# Altium schematic sheet Battery_BMS.SchDoc("Battery & BMS")
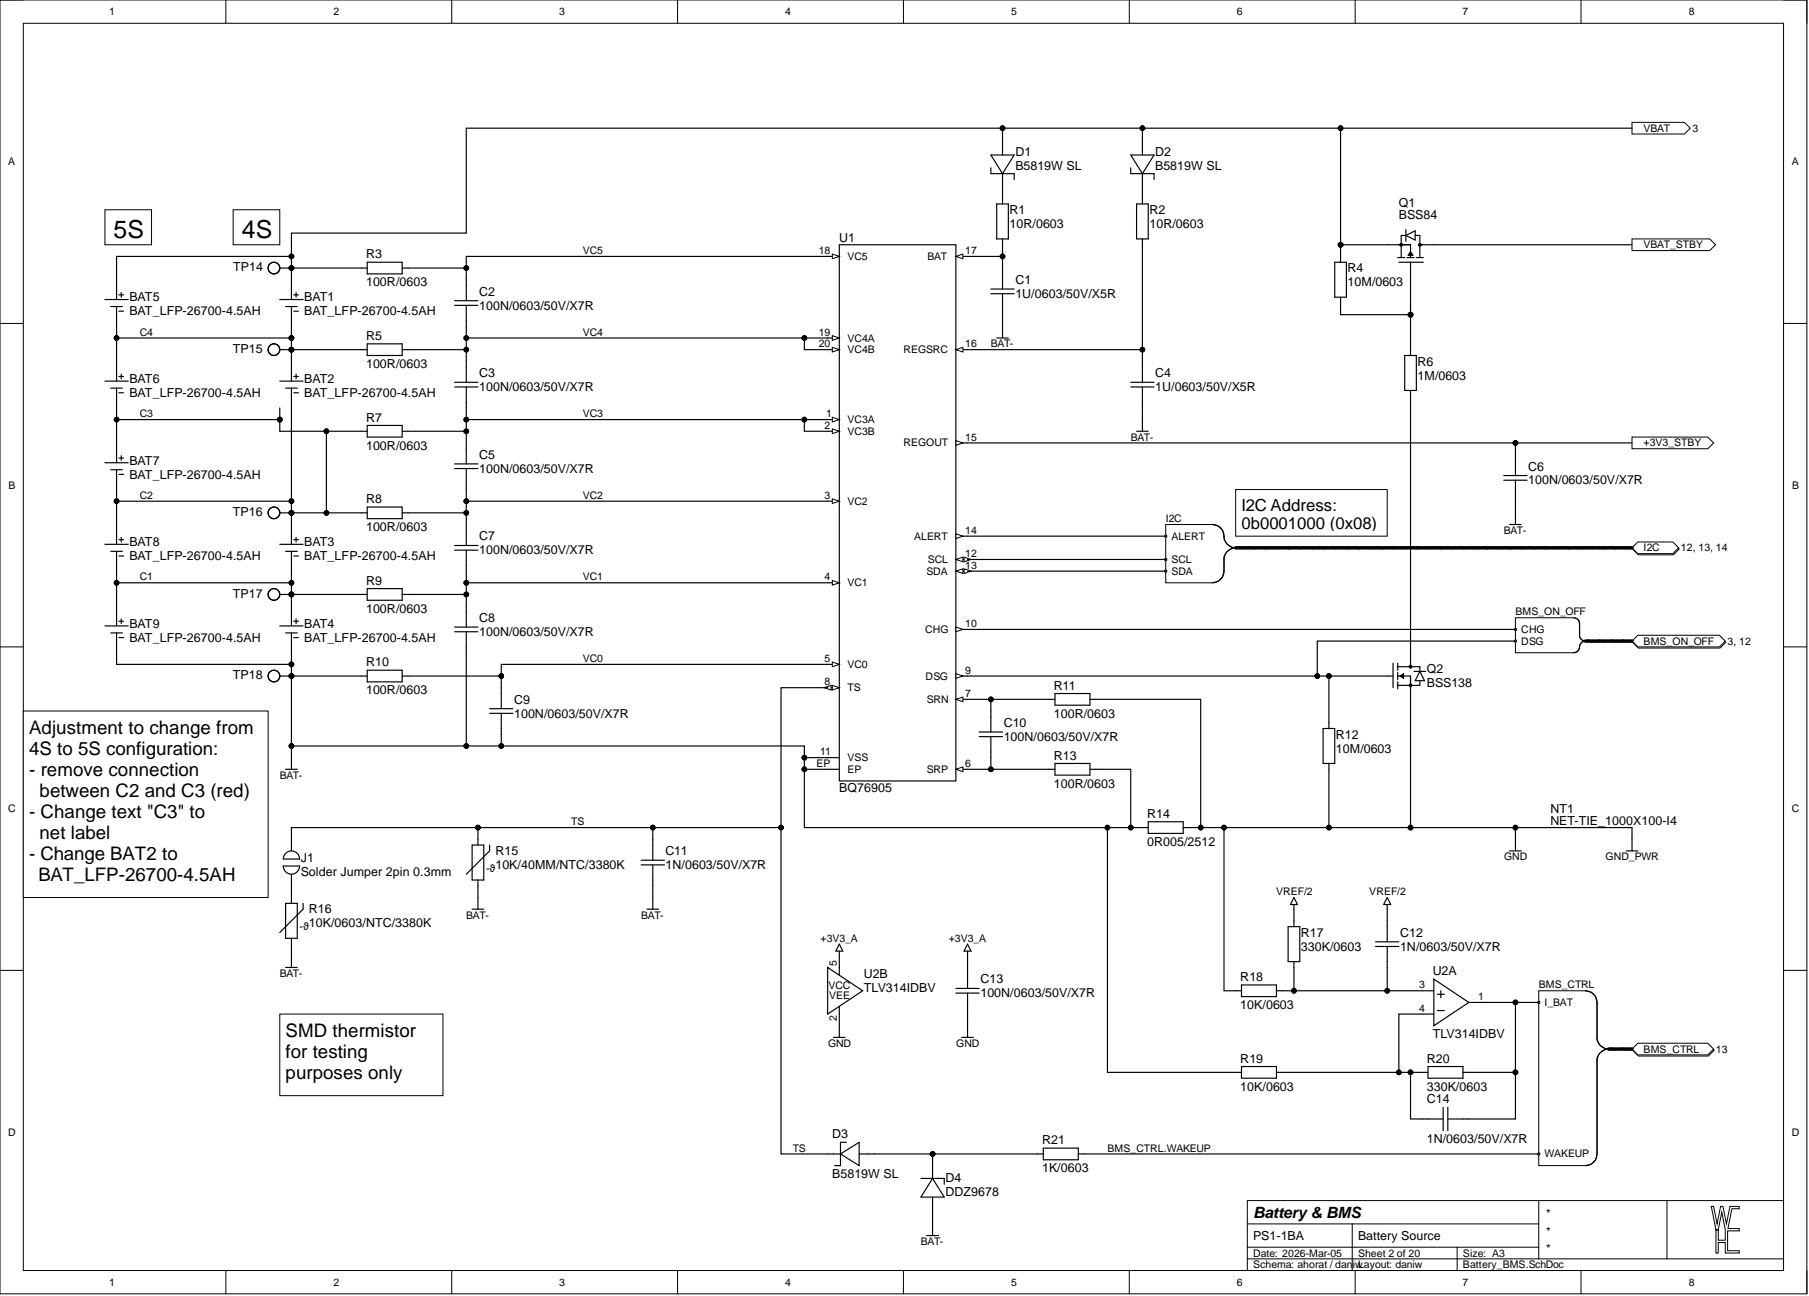
[diagram p0: Battery_BMS.SchDoc]

## Parts
BAT1  BAT_LFP-26700-4.5AH  [BAT-26700-PS]
BAT2  BAT_LFP-26700-4.5AH  [BAT-26700-PS]
BAT3  BAT_LFP-26700-4.5AH  [BAT-26700-PS]
BAT4  BAT_LFP-26700-4.5AH  [BAT-26700-PS]
C1  1U/0603/50V/X5R  [CAP0603_X7R]
C2  100N/0603/50V/X7R  [CAP0603_X7R]
C3  100N/0603/50V/X7R  [CAP0603_X7R]
C4  1U/0603/50V/X5R  [CAP0603_X7R]
C5  100N/0603/50V/X7R  [CAP0603_X7R]
C6  100N/0603/50V/X7R  [CAP0603_X7R]
C7  100N/0603/50V/X7R  [CAP0603_X7R]
C8  100N/0603/50V/X7R  [CAP0603_X7R]
C9  100N/0603/50V/X7R  [CAP0603_X7R]
C10  100N/0603/50V/X7R  [CAP0603_X7R]
C11  1N/0603/50V/X7R  [CAP0603_X7R]
C12  1N/0603/50V/X7R  [CAP0603_X7R]
C13  100N/0603/50V/X7R  [CAP0603_X7R]
C14  1N/0603/50V/X7R  [CAP0603_X7R]
D1  B5819W SL  [SOD3718X125]
D2  B5819W SL  [SOD3718X125]
D3  B5819W SL  [SOD3718X125]
D4  DDZ9678  [SOD3718X125]
J1  Solder Jumper 2pin 0.3mm  [Solder_Jumper_2pin_0.3mm]
NT1  NET-TIE_1000X100-I4  [NET-TIE_1000X100]
Q1  BSS84  [SOT2395P280X125-3-SE]
Q2  BSS138  [SOT2395P280X125-3-SE]
R1  10R/0603  [R0603-0.40-WW]
R2  10R/0603  [R0603-0.40-WW]
R3  100R/0603  [R0603-0.40-WW]
R4  10M/0603  [R0603-0.40-WW]
R5  100R/0603  [R0603-0.40-WW]
R6  1M/0603  [R0603-0.40-WW]
R7  100R/0603  [R0603-0.40-WW]
R8  100R/0603  [R0603-0.40-WW]
R9  100R/0603  [R0603-0.40-WW]
R10  100R/0603  [R0603-0.40-WW]
R11  100R/0603  [R0603-0.40-WW]
R12  10M/0603  [R0603-0.40-WW]
R13  100R/0603  [R0603-0.40-WW]
R14  0R005/2512  [R2512-0.65-WW]
R15  10K/40MM/NTC/3380K  [RESD200W25D200H4000]
R16  10K/0603/NTC/3380K  [R0603-0.40-WW]
R17  330K/0603  [R0603-0.40-WW]
R18  10K/0603  [R0603-0.40-WW]
R19  10K/0603  [R0603-0.40-WW]
R20  330K/0603  [R0603-0.40-WW]
R21  1K/0603  [R0603-0.40-WW]
TP14  TP_THD_1.5/0.8  [TP_THD_1.5/0.8]  (value not drawn)  (no BOM)
TP15  TP_THD_1.5/0.8  [TP_THD_1.5/0.8]  (value not drawn)  (no BOM)
TP16  TP_THD_1.5/0.8  [TP_THD_1.5/0.8]  (value not drawn)  (no BOM)
TP17  TP_THD_1.5/0.8  [TP_THD_1.5/0.8]  (value not drawn)  (no BOM)
TP18  TP_THD_1.5/0.8  [TP_THD_1.5/0.8]  (value not drawn)  (no BOM)
U1  BQ76905  [QFN050P350X350X100-20-EP200X200]
U2  TLV314IDBV  [SOT2395P280X125-5-NW]  (parts A,B)

## Ports
+3V3_STBY, BMS_CTRL, BMS_CTRL.I_BAT, BMS_CTRL.WAKEUP, BMS_ON_OFF, BMS_ON_OFF.CHG, BMS_ON_OFF.DSG, I2C, I2C.ALERT, I2C.SCL, I2C.SDA, VBAT, VBAT_STBY

## Nets
+3V3_A: C13.1 U2.5
BAT-: BAT4.2 C1.2 C4.2 C6.2 C8.2 C9.2 C11.2 D4.2 R10.1 R13.2 R14.1 R15.2 R16.2 R19.1 TP18.1 U1.11(VSS) U1.EP
GND: C13.2 NT1.1 Q2.2 R11.2 R12.2 R14.2 R18.1 U2.2
GND_PWR: NT1.2
VREF/2: C12.1 R17.1
+3V3_STBY: C6.1 U1.15(REGOUT) ; port +3V3_STBY
BMS_CTRL: C14.2 R20.2 U2.1 ; port BMS_CTRL
BMS_CTRL.WAKEUP: R21.2 ; port BMS_CTRL
C1: BAT3.2 BAT4.1 R9.1 TP17.1
C2: BAT2.2 BAT3.1 R7.1 R8.1 TP16.1
C4: BAT1.2 BAT2.1 R5.1 TP15.1
TS: C11.1 D3.1 J1.1 R15.1 U1.8(TS)
VBAT: BAT1.1 D1.2 D2.2 Q1.2 R3.1 R4.1 TP14.1 ; port VBAT
VBAT_STBY: Q1.3 ; port VBAT_STBY
VC0: C9.1 R10.2 U1.5(VC0)
VC1: C7.2 C8.1 R9.2 U1.4(VC1)
VC2: C5.2 C7.1 R8.2 U1.3(VC2)
VC3: C3.2 C5.1 R7.2 U1.1(VC3A) U1.2(VC3B)
VC4: C2.2 C3.1 R5.2 U1.19(VC4A) U1.20(VC4B)
VC5: C2.1 R3.2 U1.18(VC5)
NetC1_1: C1.1 R1.2 U1.17(BAT)
NetC4_1: C4.1 R2.2 U1.16(REGSRC)
NetC10_1: C10.1 R11.1 U1.7(SRN)
NetC10_2: C10.2 R13.1 U1.6(SRP)
NetC12_2: C12.2 R17.2 R18.2 U2.3
NetC14_1: C14.1 R19.2 R20.1 U2.4
NetD1_1: D1.1 R1.1
NetD2_1: D2.1 R2.1
NetD3_2: D3.2 D4.1 R21.1
NetJ1_2: J1.2 R16.1
NetQ1_1: Q1.1 R4.2 R6.1
NetQ2_1: Q2.1 R12.1 U1.9(DSG) ; port BMS_ON_OFF
NetQ2_3: Q2.3 R6.2
NetU1_10: U1.10(CHG) ; port BMS_ON_OFF
NetU1_12: U1.12(SCL) ; port I2C
NetU1_13: U1.13(SDA) ; port I2C
NetU1_14: U1.14(ALERT) ; port I2C

## Library data of the parts
BAT1-BAT4 = BAT_LFP-26700-4.5AH: Battery LiFePO4 26700 4.5Ah; Manufacturer: Tenpower; Manufacturer Part Number 1: IFR26700-45HE; Database Table Name: Misc$; Component Kind: Standard; HelpURL: https://www.tenpowercell.com/wp-content/uploads/2023/08/TP-IFR26700-45HE-Product-Datasheet-v1.4.pdf; Manufacturer 1: Tenpower; Part Number: BAT_LFP-26700-4.5AH; Supplier 1: NKON; Supplier Part Number 1: 6097321571573; Library Name: daniw_altium_lib.DbLib
C1 C4 = 1U/0603/50V/X5R: CAP SM 0603 1.0uF 50V X5R; Manufacturer: GENERIC; Manufacturer 1: Samsung Electro-Mechanics; Manufacturer Part Number 1: CL10A105KB8NNNC; Absolute Value: 1E-6; Database Table Name: C$; Eurocircuits GPN: GPC0603105-X5R; Component Kind: Standard; HelpURL: https://mm.digikey.com/Volume0/opasdata/d220001/medias/docus/658/CL10A105KB8NNNC_Spec.pdf; JLC Type: Basic; Manufacturer Part Number 3: CL10A105KB8NNNC; Package: 0603; Part Number: 1U/0603/50V/X5R; Supplier 1: Digi-Key; Supplier 3: LCSC; Supplier Part Number 1: 1276-1860-1-ND; Supplier Part Number 3: C15849; Value: 1.0uF; Library Name: daniw_altium_lib.DbLib
C2 C3 C5-C10 C13 = 100N/0603/50V/X7R: CAP SM 0603 100nF 50V X7R; Manufacturer: GENERIC; Manufacturer 1: YAGEO; Manufacturer Part Number 1: CC0603KPX7R9BB104; Absolute Value: 1E-7; Database Table Name: C$; Eurocircuits GPN: GPC0603104; Component Kind: Standard; HelpURL: https://www.yageo.com/upload/media/product/app/datasheet/mlcc/upy-gphc_x7r_6_3v-to-250v.pdf; JLC Type: Basic; Manufacturer 2: YAGEO; Manufacturer Part Number 2: CC0603MRX7R9BB104; Manufacturer Part Number 3: CC0603KRX7R9BB104; Package: 0603; Part Number: 100N/0603/50V/X7R; Supplier 1: Digi-Key; Supplier 2: Digi-Key; Supplier 3: LCSC; Supplier Part Number 1: 311-3567-1-ND; Supplier Part Number 2: 311-4110-1-ND; Supplier Part Number 3: C14663; Value: 100nF; Library Name: daniw_altium_lib.DbLib
C11 C12 C14 = 1N/0603/50V/X7R: CAP SM 0603 1.0nF 50V X7R; Manufacturer: GENERIC; Manufacturer 1: YAGEO; Manufacturer Part Number 1: CC0603KPX7R9BB102; Absolute Value: 1E-9; Database Table Name: C$; Eurocircuits GPN: GPC0603102; Component Kind: Standard; HelpURL: https://www.yageo.com/upload/media/product/app/datasheet/mlcc/upy-gphc_x7r_6_3v-to-250v.pdf; JLC Type: Basic; Manufacturer 2: YAGEO; Manufacturer Part Number 2: CC0603KRX7R9BB102; Manufacturer Part Number 3: CL10B102KB8NNNC; Package: 0603; Part Number: 1N/0603/50V/X7R; Supplier 1: Digi-Key; Supplier 2: Digi-Key; Supplier 3: LCSC; Supplier Part Number 1: 13-CC0603KPX7R9BB102CT-ND; Supplier Part Number 2: 311-1080-1-ND; Supplier Part Number 3: C1588; Value: 1.0nF; Library Name: daniw_altium_lib.DbLib
D1-D3 = B5819W SL: 40V 600mV@1A 1A SOD-123 Schottky Barrier Diodes; Manufacturer: GENERIC; Manufacturer 1: SMC Diode Solutions; Database Table Name: D$; Absolute Value: 40V; Component Kind: Standard; HelpURL: https://datasheet.lcsc.com/lcsc/2304140030_Jiangsu-Changjing-Electronics-Technology-Co---Ltd--B5819W-SL_C8598.pdf; JLC Type: Basic; Manufacturer 3: Jiangsu Changjing Electronics Technology Co., Ltd.; Manufacturer Part Number 1: B5819WSL; Manufacturer Part Number 3: B5819W SL; Package: SOD-123; Part Number: B5819W SL; Supplier 1: Digikey; Supplier 3: LCSC; Supplier Part Number 1: 1655-B5819WSLCT-ND; Supplier Part Number 3: C8598; Value: B5819W SL; Library Name: daniw_altium_lib.DbLib
D4 = DDZ9678: Low current zener diode 1.8V 500mW SOD123; Manufacturer: Diodes Incorporated; Manufacturer Part Number 1: DDZ9678-7; Database Table Name: D$; Absolute Value: 1.8V; Component Kind: Standard; HelpURL: https://www.diodes.com/datasheet/download/DDZ96xx-DDZ97xx.pdf; JLC Type: Extended; Manufacturer 1: Diodes Incorporated; Manufacturer 3: DIODES; Manufacturer Part Number 3: DDZ9678-7; Package: SOD-123; Part Number: DDZ9678; Supplier 1: Digikey; Supplier 3: LCSC; Supplier Part Number 1: DDZ9678DICT-ND; Supplier Part Number 3: C150464; Value: 1.8V; Library Name: daniw_altium_lib.DbLib
J1 = Solder_Jumper_2pin_0.3mm: Solder jumper with two pins with a spacing of 0.3mm; Manufacturer: NONE; Database Table Name: Misc$; Component Kind: Standard; Part Number: Solder_Jumper_2pin_0.3mm; Library Name: daniw_altium_lib.DbLib
NT1 = NET-TIE_1000X100-I4: Net-Tie 10.00mmx1.00mm Inner Layer 4; Manufacturer: NONE; Database Table Name: Net-Tie$; Component Kind: Net Tie; Layer: Inner Layer 4; Length: 1.00mm; Part Number: NET-TIE_1000X100-I4; Width: 10.00m; Library Name: daniw_altium_lib.DbLib
Q1 = BSS84: 50V P-Channel MOSFET; Manufacturer: GENERIC; Manufacturer 1: Good-Ark Semiconductor; Database Table Name: T$; Component Kind: Standard; HelpURL: https://goodarksemi.com/docs/datasheets/mosfets/BSS84.pdf; JLC Type: Extended; Manufacturer 2: LRC; Manufacturer 3: Jiangsu Changjing Electronics Technology Co., Ltd.; Manufacturer Part Number 1: BSS84; Manufacturer Part Number 2: LBSS84LT1G; Manufacturer Part Number 3: BSS84; Package: SOT23; Part Number: BSS84; Supplier 1: Digi-Key; Supplier 2: LCSC; Supplier 3: LCSC; Supplier Part Number 1: 4786-BSS84CT-ND; Supplier Part Number 2: C8492; Supplier Part Number 3: C114481; Library Name: daniw_altium_lib.DbLib
Q2 = BSS138: N-Kanal 50 V 220mA (Ta) 350mW (Ta) Oberflächenmontage SOT-23; Manufacturer: GENERIC; Manufacturer 1: ANBON SEMICONDUCTOR (INT'L) LIMITED; Database Table Name: T$; Component Kind: Standard; HelpURL: https://mm.digikey.com/Volume0/opasdata/d220001/medias/docus/3037/BSS138.pdf; JLC Type: Extended; Manufacturer 2: FOSAN; Manufacturer 3: FOSAN; Manufacturer Part Number 1: BSS138; Manufacturer Part Number 2: BSS138; Manufacturer Part Number 3: BSS138; Package: SOT23; Part Number: BSS138; Supplier 1: Digi-Key; Supplier 2: LCSC; Supplier 3: LCSC; Supplier Part Number 1: 4530-BSS138CT-ND; Supplier Part Number 2: C2926186; Supplier Part Number 3: C2926186; Library Name: daniw_altium_lib.DbLib
R1 R2 = 10R/0603: RES SM 0603 10R; Manufacturer: GENERIC; Manufacturer 1: YAGEO; Manufacturer Part Number 1: RC0603FR-1310RL; Absolute Value: 10; Database Table Name: R$; Eurocircuits GPN: GPR060310R; Component Kind: Standard; HelpURL: https://www.yageogroup.com/content/datasheet/asset/file/PYU-RC_GROUP_51_ROHS_L; JLC Type: Basic; Manufacturer 2: YAGEO; Manufacturer 3: UNI-ROYAL; Manufacturer Part Number 2: RC0603FR-1010RL; Manufacturer Part Number 3: 0603WAF100JT5E; Package: 0603; Part Number: 10R/0603; Supplier 1: Digi-Key; Supplier 2: Digi-Key; Supplier 3: LCSC; Supplier Part Number 1: 13-RC0603FR-1310RLCT-ND; Supplier Part Number 2: 13-RC0603FR-1010RLCT-ND; Supplier Part Number 3: C22859; Value: 10R; Library Name: daniw_altium_lib.DbLib
R3 R5 R7-R11 R13 = 100R/0603: RES SM 0603 100R; Manufacturer: GENERIC; Manufacturer 1: YAGEO; Manufacturer Part Number 1: RC0603FR-13100RL; Absolute Value: 100; Database Table Name: R$; Eurocircuits GPN: GPR0603100R; Component Kind: Standard; HelpURL: https://www.yageogroup.com/content/datasheet/asset/file/PYU-RC_GROUP_51_ROHS_L; Manufacturer 2: YAGEO; Manufacturer 3: UNI-ROYAL(Uniroyal Elec); Manufacturer Part Number 2: RC0603FR-10100RL; Manufacturer Part Number 3: 0603WAF1000T5E; Package: 0603; Part Number: 100R/0603; Supplier 1: Digi-Key; Supplier 2: Digi-Key; Supplier 3: LCSC; Supplier Part Number 1: 13-RC0603FR-13100RLCT-ND; Supplier Part Number 2: 13-RC0603FR-10100RLCT-ND; Supplier Part Number 3: C22775; Value: 100R; Library Name: daniw_altium_lib.DbLib
R4 R12 = 10M/0603: RES SM 0603 10M; Manufacturer: GENERIC; Manufacturer 1: YAGEO; Manufacturer Part Number 1: RC0603FR-1310ML; Absolute Value: 10000000; Database Table Name: R$; Eurocircuits GPN: GPR060310M; Component Kind: Standard; HelpURL: https://www.yageogroup.com/content/datasheet/asset/file/PYU-RC_GROUP_51_ROHS_L; JLC Type: Basic; Manufacturer 2: YAGEO; Manufacturer 3: UNI-ROYAL(Uniroyal Elec); Manufacturer Part Number 2: RC0603FR-1010ML; Manufacturer Part Number 3: 0603WAF1005T5E; Package: 0603; Part Number: 10M/0603; Supplier 1: Digi-Key; Supplier 2: Digi-Key; Supplier 3: LCSC; Supplier Part Number 1: 13-RC0603FR-1310MLCT-ND; Supplier Part Number 2: 13-RC0603FR-1010MLCT-ND; Supplier Part Number 3: C7250; Value: 10M; Library Name: daniw_altium_lib.DbLib
R6 = 1M/0603: RES SM 0603 1M; Manufacturer: GENERIC; Manufacturer 1: YAGEO; Manufacturer Part Number 1: RC0603FR-131ML; Absolute Value: 1000000; Database Table Name: R$; Eurocircuits GPN: GPR06031M; Component Kind: Standard; HelpURL: https://www.yageogroup.com/content/datasheet/asset/file/PYU-RC_GROUP_51_ROHS_L; JLC Type: Basic; Manufacturer 2: YAGEO; Manufacturer 3: UNI-ROYAL(Uniroyal Elec); Manufacturer Part Number 2: RC0603FR-101ML; Manufacturer Part Number 3: 0603WAF1004T5E; Package: 0603; Part Number: 1M/0603; Supplier 1: Digi-Key; Supplier 2: Digi-Key; Supplier 3: LCSC; Supplier Part Number 1: 13-RC0603FR-131MLCT-ND; Supplier Part Number 2: 13-RC0603FR-101MLCT-ND; Supplier Part Number 3: C22935; Value: 1M; Library Name: daniw_altium_lib.DbLib
R14 = 0R005/2512: RES SM 2512 0R005; Manufacturer: GENERIC; Manufacturer 1: Ohmite; Manufacturer Part Number 1: MCS3264R005FER; Absolute Value: 0.005; Database Table Name: R$; Component Kind: Standard; HelpURL: https://www.ohmite.com/assets/docs/res_mcs.pdf?r=false; Manufacturer 3: prosemi; Manufacturer Part Number 3: LMP25MF2P0R005; Package: 2512; Part Number: 0R005/2512; Supplier 1: Digi-Key; Supplier 3: LCSC; Supplier Part Number 1: MCS3264R005FERCT-ND; Supplier Part Number 3: C2937402; Value: 0R005; Library Name: daniw_altium_lib.DbLib
R15 = 10K/40MM/NTC/3380K: RES NTC THT 40mm 10K 3380K; Manufacturer: GENERIC; Manufacturer 1: Eaton - Electronics Division; Manufacturer Part Number 1: NRSE104F3380B1F; Absolute Value: 10000; Database Table Name: R$; Component Kind: Standard; HelpURL: https://eaton.com/content/dam/eaton/products/electronic-components/resources/data-sheet/eaton-nrse-epoxy-sealed-radial-lead-ntc-thermistor-data-sheet-elx1227.pdf; Part Number: 10K/40MM/NTC/3380K; Supplier 1: Digi-Key; Supplier Part Number 1: 283-NRSE104F3380B1F-ND; Value: 10K; Library Name: daniw_altium_lib.DbLib
R16 = 10K/0603/NTC/3380K: RES NTC SM 0603 10K; Manufacturer: GENERIC; Manufacturer 1: Murata; Manufacturer Part Number 1: NCU18XH103E60RB; Absolute Value: 10000; Database Table Name: R$; Eurocircuits GPN: GPR060310K; Component Kind: Standard; HelpURL: https://www.murata.com/en-us/api/pdfdownloadapi?cate=luNTCforTempeSenso&partno=NCU18XH103E60RB; JLC Type: Extended; Manufacturer 3: Sunlord; Manufacturer Part Number 3: SDNT1608X103F3380FTF; Package: 0603; Part Number: 10K/0603/NTC/3380K; Supplier 1: Digi-Key; Supplier 3: LCSC; Supplier Part Number 1: 490-18164-1-ND; Supplier Part Number 3: C316397; Value: 10K; Library Name: daniw_altium_lib.DbLib
R17 R20 = 330K/0603: RES SM 0603 330K; Manufacturer: GENERIC; Manufacturer 1: YAGEO; Manufacturer Part Number 1: RC0603FR-13330KL; Absolute Value: 330000; Database Table Name: R$; Eurocircuits GPN: GPR0603330K; Component Kind: Standard; HelpURL: https://www.yageogroup.com/content/datasheet/asset/file/PYU-RC_GROUP_51_ROHS_L; JLC Type: Basic; Manufacturer 2: YAGEO; Manufacturer 3: YAGEO; Manufacturer Part Number 2: RC0603FR-10330KL; Manufacturer Part Number 3: RC0603FR-07330KL; Package: 0603; Part Number: 330K/0603; Supplier 1: Digi-Key; Supplier 2: Digi-Key; Supplier 3: LCSC; Supplier Part Number 1: 13-RC0603FR-13330KLCT-ND; Supplier Part Number 2: 13-RC0603FR-10330KLCT-ND; Supplier Part Number 3: C114618; Value: 330K; Library Name: daniw_altium_lib.DbLib
R18 R19 = 10K/0603: RES SM 0603 10K; Manufacturer: GENERIC; Manufacturer 1: YAGEO; Manufacturer Part Number 1: RC0603FR-1310KL; Absolute Value: 10000; Database Table Name: R$; Eurocircuits GPN: GPR060310K; Component Kind: Standard; HelpURL: https://www.yageogroup.com/content/datasheet/asset/file/PYU-RC_GROUP_51_ROHS_L; JLC Type: Basic; Manufacturer 2: YAGEO; Manufacturer 3: UNI-ROYAL(Uniroyal Elec); Manufacturer Part Number 2: RC0603FR-1010KL; Manufacturer Part Number 3: 0603WAF1002T5E; Package: 0603; Part Number: 10K/0603; Supplier 1: Digi-Key; Supplier 2: Digi-Key; Supplier 3: LCSC; Supplier Part Number 1: 13-RC0603FR-1310KLCT-ND; Supplier Part Number 2: 13-RC0603FR-1010KLCT-ND; Supplier Part Number 3: C25804; Value: 10K; Library Name: daniw_altium_lib.DbLib
R21 = 1K/0603: RES SM 0603 1K; Manufacturer: GENERIC; Manufacturer 1: YAGEO; Manufacturer Part Number 1: RC0603FR-131KL; Absolute Value: 1000; Database Table Name: R$; Eurocircuits GPN: GPR06031K; Component Kind: Standard; HelpURL: https://www.yageogroup.com/content/datasheet/asset/file/PYU-RC_GROUP_51_ROHS_L; JLC Type: Basic; Manufacturer 2: YAGEO; Manufacturer 3: UNI-ROYAL(Uniroyal Elec); Manufacturer Part Number 2: RC0603FR-101KL; Manufacturer Part Number 3: 0603WAF1001T5E; Package: 0603; Part Number: 1K/0603; Supplier 1: Digi-Key; Supplier 2: Digi-Key; Supplier 3: LCSC; Supplier Part Number 1: 13-RC0603FR-131KLCT-ND; Supplier Part Number 2: 13-RC0603FR-101KLCT-ND; Supplier Part Number 3: C21190; Value: 1K; Library Name: daniw_altium_lib.DbLib
TP14-TP18 = TP_THD_1.5/0.8: THT Test Point 1.5/0.8mm; Manufacturer: NONE; Database Table Name: Misc$; Part Number: TP_THD_1.5/0.8; Library Name: daniw_altium_lib.DbLib
U1 = BQ76905: BQ76905 2-Series to 5-Series High Accuracy Battery Monitor and Protector for Li-Ion, Li-Polymer, LiFePO4 (LFP), and LTO Battery Packs; Manufacturer: Texas Instruments; Manufacturer Part Number 1: BQ76905RGRR; Database Table Name: Integrated$; Component Kind: Standard; HelpURL: https://www.ti.com/lit/ds/symlink/bq76905.pdf; Manufacturer 1: Texas Instruments; Manufacturer 3: Texas Instruments; Manufacturer Part Number 3: BQ76905RGRR; Part Number: BQ76905; Supplier 1: Digi-Key; Supplier 3: LCSC; Supplier Part Number 1: 296-BQ76905RGRRCT-ND; Supplier Part Number 3: C22394458; Library Name: daniw_altium_lib.DbLib
U2 = TLV314 SOT23: TLV314, 3-MHz, Low-Power, Internal EMI Filter, RRIO, Operational Amplifier; Manufacturer: Texas Instruments; Manufacturer Part Number 1: TLV314IDBVR; Database Table Name: Integrated$; Component Kind: Standard; HelpURL: https://www.ti.com/lit/ds/symlink/tlv4314.pdf; Manufacturer 1: Texas Instruments; Manufacturer 2: Texas Instruments; Manufacturer 3: Texas Instruments; Manufacturer Part Number 2: TLV314IDBVT; Manufacturer Part Number 3: TLV314IDBVR; Package: SOT23-5; Part Number: TLV314 SOT23; Supplier 1: Digi-Key; Supplier 2: Digi-Key; Supplier 3: LCSC; Supplier Part Number 1: 296-46275-1-ND; Supplier Part Number 2: 296-44110-2-ND; Supplier Part Number 3: C133032; Library Name: daniw_altium_lib.DbLib
